# Altium schematic sheet 04_MCU.SchDoc(04_MCU)
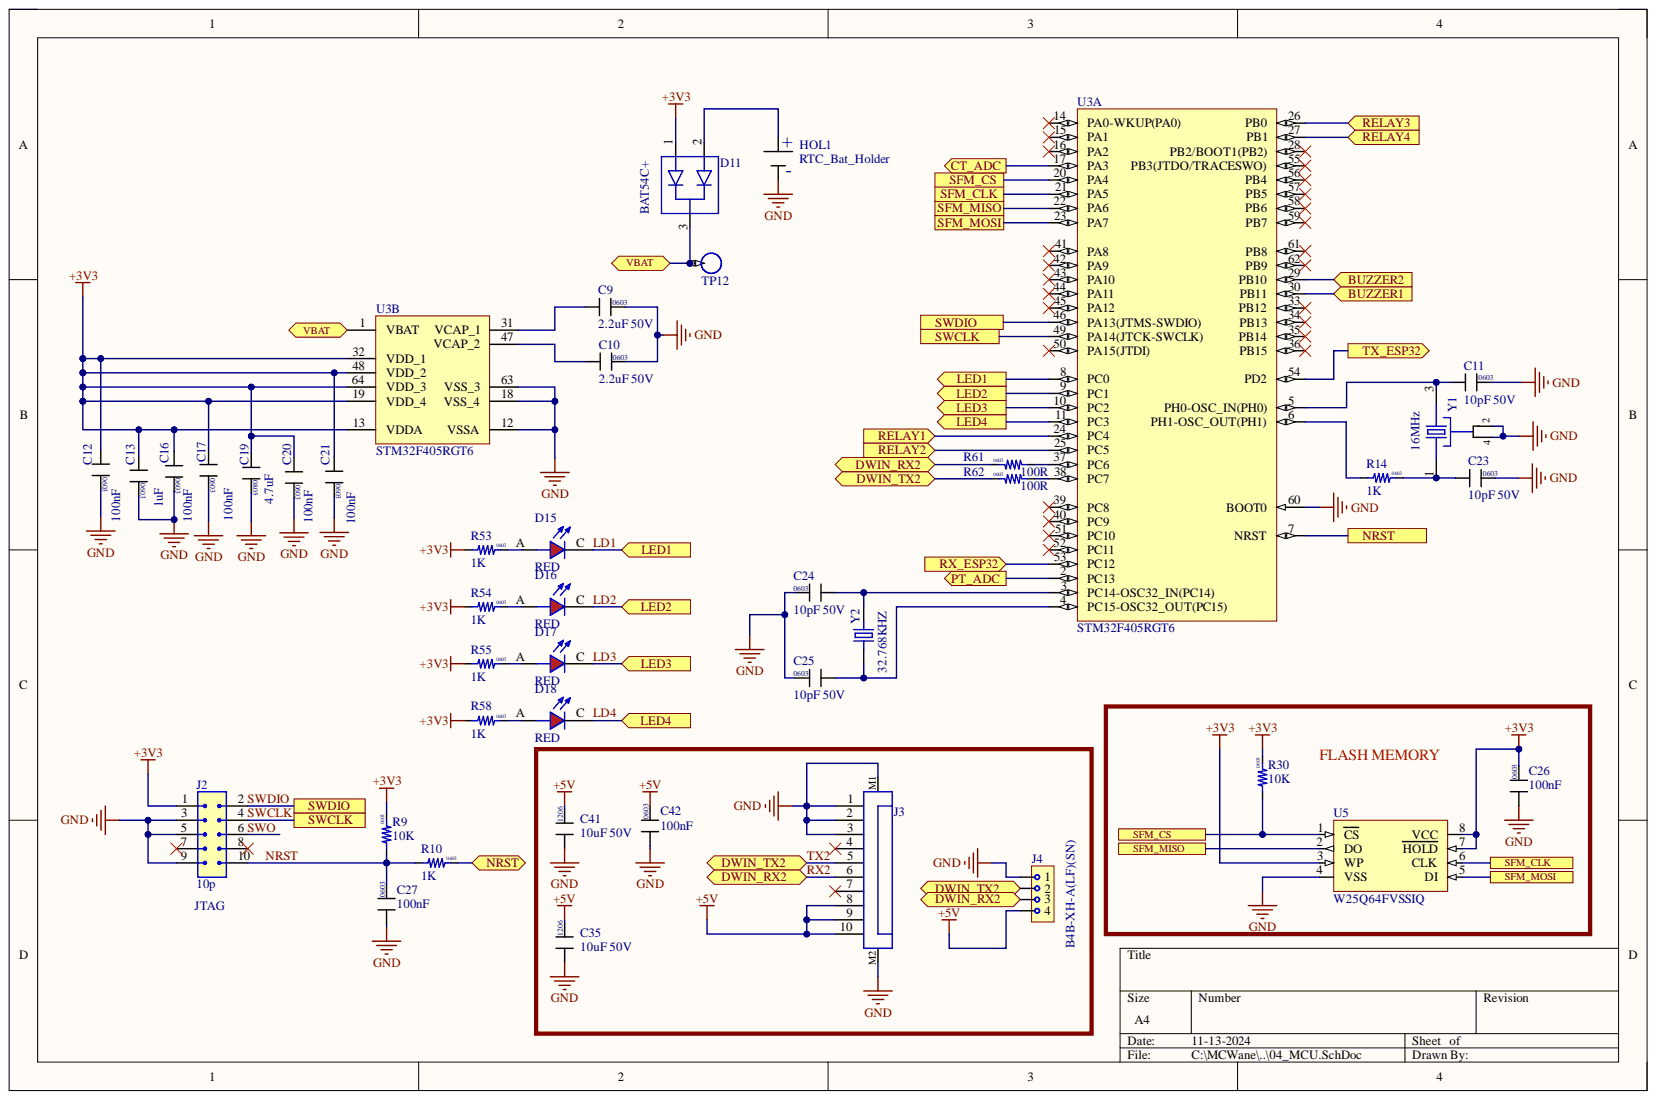
[diagram p0: 04_MCU.SchDoc]

## Parts
C9  2.2uF 50V  Package: 0603  [CAP_0603]
C10  2.2uF 50V  Package: 0603  [CAP_0603]
C11  10pF 50V  Package: 0603  [CAP_0603]
C12  100nF  Package: 0603  [CAP_0603]
C13  1uF  Package: 0603  [CAP_0603]
C16  100nF  Package: 0603  [CAP_0603]
C17  100nF  Package: 0603  [CAP_0603]
C19  4.7uF  Package: 0805  [CAP_0805]
C20  100nF  Package: 0603  [CAP_0603]
C21  100nF  Package: 0603  [CAP_0603]
C23  10pF 50V  Package: 0603  [CAP_0603]
C24  10pF 50V  Package: 0603  [CAP_0603]
C25  10pF 50V  Package: 0603  [CAP_0603]
C26  100nF  Package: 0603  [CAP_0603]
C27  100nF  Package: 0603  [CAP_0603]
C35  10uF 50V  Package: 1206  [CAP_1206]
C41  10uF 50V  Package: 1206  [CAP_1206]
C42  100nF  Package: 0603  [CAP_0603]
D11  BAT54C+  [SOT23]
D15  RED  [LED_0603]
D16  RED  [LED_0603]
D17  RED  [LED_0603]
D18  RED  [LED_0603]
HOL1  RTC_Bat_Holder  [RTC_BAT_20mm_VER]
J2  10p  [FTS-105-01-F-DV]
J3  68611014422  [FPC_10PIN_1mm_BC]  (value not drawn)
J4  B4B-XH-A(LF)(SN)  [RMC_4_PIN_M_V_S_2.54mm]
R9  10K  Package: 0603  [RES_0603]
R10  1K  Package: 0603  [RES_0603]
R14  1K  Package: 0603  [RES_0603]
R30  10K  Package: 0603  [RES_0603]
R53  1K  Package: 0603  [RES_0603]
R54  1K  Package: 0603  [RES_0603]
R55  1K  Package: 0603  [RES_0603]
R58  1K  Package: 0603  [RES_0603]
R61  100R  Package: 0603  [RES_0603]
R62  100R  Package: 0603  [RES_0603]
TP12  TP  [TEST_POINT_SMD]
U3  STM32F405RGT6  [STM-LQFP64_N]  (parts A,B)
U5  W25Q64FVSSIQ  [SOIC-8W]
Y1  16MHz  [XTAL_3.2X2.5mm]
Y2  32.768KHZ  [XTAL_ABS07-32.768KHZ-T]

## Ports
BUZZER1, BUZZER2, CT_ADC, DWIN_RX2, DWIN_TX2, LED1, LED2, LED3, LED4, NRST, PT_ADC, RELAY1, RELAY2, RELAY3, RELAY4, RX_ESP32, SFM_CLK, SFM_CS, SFM_MISO, SFM_MOSI, SWCLK, SWDIO, TX_ESP32, VBAT

## Nets
+3V3: C12.1 C13.1 C16.1 C17.1 C19.1 C20.1 C21.1 C26.2 D11.1 J2.1 R9.2 R30.2 R53.1 R54.1 R55.1 R58.1 U3.13(VDDA) U3.19(VDD_4) U3.32(VDD_1) U3.48(VDD_2) U3.64(VDD_3) U5.3(WP) U5.7(HOLD) U5.8(VCC)
+5V: C35.2 C41.2 C42.2 J3.8 J3.9 J3.10 J4.4
GND: C9.2 C10.2 C11.2 C12.2 C13.2 C16.2 C17.2 C19.2 C20.2 C21.2 C23.2 C24.2 C25.2 C26.1 C27.1 C35.1 C41.1 C42.1 HOL1.2 J2.3 J2.5 J2.9 J3.1 J3.2 J3.3 J3.M1 J3.M2 J4.1 U3.12(VSSA) U3.18(VSS_4) U3.60(BOOT0) U3.63(VSS_3) U5.4(VSS) Y1.2 Y1.4
BUZZER1: U3.30(PB11) ; port BUZZER1
BUZZER2: U3.29(PB10) ; port BUZZER2
CT_ADC: U3.17(PA3) ; port CT_ADC
LD1: D15.C U3.8(PC0) ; port LED1
LD2: D16.C U3.9(PC1) ; port LED2
LD3: D17.C U3.10(PC2) ; port LED3
LD4: D18.C U3.11(PC3) ; port LED4
NRST: C27.2 J2.10 R9.1 R10.1
PT_ADC: U3.2(PC13) ; port PT_ADC
RELAY1: U3.24(PC4) ; port RELAY1
RELAY2: U3.25(PC5) ; port RELAY2
RELAY3: U3.26(PB0) ; port RELAY3
RELAY4: U3.27(PB1) ; port RELAY4
RX2: J3.6 J4.3 R61.2 ; port DWIN_RX2
RX_ESP32: U3.53(PC12) ; port RX_ESP32
SFM_CLK: U3.21(PA5) U5.6(CLK) ; port SFM_CLK
SFM_CS: R30.1 U3.20(PA4) U5.1(CS) ; port SFM_CS
SFM_MISO: U3.22(PA6) U5.2(DO) ; port SFM_MISO
SFM_MOSI: U3.23(PA7) U5.5(DI) ; port SFM_MOSI
SWCLK: J2.4 U3.49(PA14(JTCK-SWCLK)) ; port SWCLK
SWDIO: J2.2 U3.46(PA13(JTMS-SWDIO)) ; port SWDIO
SWO: J2.6
TX2: J3.5 J4.2 R62.2 ; port DWIN_TX2
TX_ESP32: U3.54(PD2) ; port TX_ESP32
VBAT: D11.3 TP12.1 U3.1(VBAT) ; port VBAT
NetC9_1: C9.1 U3.31(VCAP_1)
NetC10_1: C10.1 U3.47(VCAP_2)
NetC11_1: C11.1 U3.5(PH0-OSC_IN(PH0)) Y1.3
NetC23_1: C23.1 R14.2 Y1.1
NetC24_1: C24.1 U3.3(PC14-OSC32_IN(PC14)) Y2.2
NetC25_1: C25.1 U3.4(PC15-OSC32_OUT(PC15)) Y2.1
NetD11_2: D11.2 HOL1.1
NetD15_A: D15.A R53.2
NetD16_A: D16.A R54.2
NetD17_A: D17.A R55.2
NetD18_A: D18.A R58.2
NetR10_2: R10.2 U3.7(NRST) ; port NRST
NetR14_1: R14.1 U3.6(PH1-OSC_OUT(PH1))
NetR61_1: R61.1 U3.37(PC6)
NetR62_1: R62.1 U3.38(PC7)

Not connected: J2 7 8; J3 4 7; U3 14(PA0-WKUP(PA0)) 15(PA1) 16(PA2) 28(PB2/BOOT1(PB2)) 33(PB12) 34(PB13) 35(PB14) 36(PB15) 39(PC8) 40(PC9) 41(PA8) 42(PA9) 43(PA10) 44(PA11) 45(PA12) 50(PA15(JTDI)) 51(PC10) 52(PC11) 55(PB3(JTDO/TRACESWO)) 56(PB4) 57(PB5) 58(PB6) 59(PB7) 61(PB8) 62(PB9)

## Library data of the parts
C9 C10 = C0603-2.2uF 50V-MLCC: SMD Multilayer Ceramic Capacitor, 2.2 µF, 50 V, 0603 [1608 Metric], ± 10%, X7R; Manufacturer Part Number: CC0603KRX5R9BB225; Brand: YAGEO; Marking: 225; Component: Capacitor; Component Kind: Standard; LCSC Part: C326557; Library Name: Passive_Components.SchLib; Supplier: ELEMENT 14
C11 C23-C25 = C0603-10pF 50V-MLCC: SMD Multilayer Ceramic Capacitor, 10 pF, 50 V, 0603 [1608 Metric], ± 10%, C0G / NP0, -55 °C; Manufacturer Part Number: CC0603KRNPO9BN100; Brand: YAGEO; Marking: 100; Component: Capacitor; Component Kind: Standard; Library Name: Passive_Components.SchLib; Supplier: ELEMENT 14; Supplier PN: C106245
C12 C16 C17 C20 C21 C26 C27 C42 = C0603-0.1uF 50V-MLCC: SMD Multilayer Ceramic Capacitor, 0.1 µF, 50 V, 0603 [1608 Metric], ± 10%, X7R; Manufacturer Part Number: CC0603KRX7R9BB104; Brand: YAGEO; Marking: 104; Component: Capacitor; Component Kind: Standard; LCSC Part: C14663; Library Name: Passive_Components.SchLib; Supplier: ELEMENT 14
C13 = C0603-1uF 50V-MLCC: SMD Multilayer Ceramic 1 µF ±10% 50V Ceramic Capacitor X7R 0603 (2012 Metric); Manufacturer Part Number: CC0603KRX7R9BB105; Brand: YAGEO; Marking: 105; Component: Capacitor; Component Kind: Standard; LCSC Part: C559769; Library Name: Passive_Components.SchLib; Supplier: ELEMENT 14
C19 = C0805-4.7uF 25V-MLCC: SMD Multilayer Ceramic Capacitor, 4.7 µF, 25 V, 0805 [2012 Metric], ± 10%, X7R, -55 °C; Manufacturer Part Number: CC0805KKX7R8BB475; Brand: YAGEO; Marking: 475; Suppplier: ELEMENT 14; Component: Capacitor; Component Kind: Standard; Library Name: Passive_Components.SchLib
C35 C41 = C1206-10uF 50V-MLCC: SMD Multilayer Ceramic Capacitor, 10 µF, 50 V, 1206 [3216 Metric], ± 10%, X5R, GRT; Manufacturer Part Number: GRT31CR61H106KE01L; Brand: MURATA; Marking: 106; Component: Capacitor; Component Kind: Standard; Library Name: Passive_Components.SchLib; Supplier: ELEMENT 14
D11 = BAT54C+: Small Signal Schottky Diode, Dual Common Cathode, 30 V, 200 mA, 400 mV, 600 mA, 125 °C; Brand: MULTICOMP PRO; Component Kind: Standard; Library Name: Active_Components.SchLib; Manufacturer Part Number: BAT54C+; Package: SOT-23
D15-D18 = RED_LED_0603: 5mA 28.5mcd 2.2V 632nm Colorless transparence -40℃~+85℃ 617.5nm~633.5nm Red 120° 60mW 0603(LED); Manufacturer Part Number: 19-217/R6C-AL1M2VY/3T; Brand: Everlight Elec; Component Kind: Standard; Library Name: Active_Components.SchLib; Package: 0603
HOL1 = RTC_Bat_Holder: Battery Holder, PCB, Vertical, CR2032; Manufacturer Part Number: MP000363; Brand: MULTICOMP PRO; Component Kind: Standard; Library Name: CONNECTOR.SchLib; Package: Through Hole
J2 = FTS-105-01-F-DV: Connector Header Surface Mount 10 position 0.050" (1.27mm); Manufacturer: Samtec Inc.; Value: 5X2 POS, 1.27mmP; Component Kind: Standard; Datasheet Web: http://suddendocs.samtec.com/catalog_english/fts.pdf; LCSC Part: C3348793; Operating Temperature: -55°C ~ 125°C; Package: SMD; PartNumber: FTS-105-01-F-DV; Type: Header - Male; Library Name: Amerex Electronics.SchLib
J3 = FPC_10PIN_1mm_BC: Horizontal Bottom Contact, pitch 1mm, Horizontal, 10pins; Brand: Wurth Elektronik; Contact Resistance: 20mΩ; Match Code: WR-FPC; Packag: SMD; Rated Current: 1A; Working Voltage: 125VAC; Category: ZIF Connectors; Component Kind: Standard; ComponentLink1Description: Website Link; ComponentLink1URL: https://www.we-online.com/redexpert/article/68611014422?ad; ComponentLink2Description: Datasheet Link; ComponentLink2URL: https://www.we-online.com/redexpert/spec/68611014422?ad; Height: 3mm; Length: 17mm; Library Name: CONNECTOR.SchLib; Manufacturer Part Number: 68611014422; Mount: Surface Mount; Number of Pins: 10; Operating Temperature Max: 85°C; Operating Temperature Min: -25°C; Orientation: Horizontal; Pitch: 1mm; Width: 7.1mm
J4 = RMC_4_PIN_M_V_S_2.54mm: Pin Header, Vertical, Wire-to-Board, 2.5 mm, 1 Rows, 4 Contacts, Through Hole Straight, XH; Brand: JST Sales America Inc.; Component Kind: Standard; Library Name: CONNECTOR.SchLib; Manufacturer Part Number: B4B-XH-A(LF)(SN); Package: TH
R9 R30 = R0603-10K-1%: SMD Chip Resistor, 10 kohm, ± 1%, 100 mW, 0603 [1608 Metric], Thick Film, General Purpose; Manufacturer Part Number: RC0603FR-0710KL; Brand: YAGEO; Marking: 1002; Component: Resistor; Component Kind: Standard; LCSC Part: C98220; Library Name: Passive_Components.SchLib; Supplier: ELEMENT 14
R10 R14 R53-R55 R58 = R0603-1K-1%: SMD Chip Resistor, 1 kohm, ± 1%, 100 mW, 0603 [1608 Metric], Thick Film, General Purpose; Manufacturer Part Number: RC0603FR-071KL; Brand: YAGEO; Marking: 1001; Component: Resistor; Component Kind: Standard; LCSC Part: C109318; Library Name: Passive_Components.SchLib; Supplier: ELEMENT 14
R61 R62 = R0603-100E-1%: SMD Chip Resistor, 100 ohm, ± 1%, 100 mW, 0603 [1608 Metric], Thick Film, General Purpose; Manufacturer Part Number: RC0603FR-07100RL; Brand: YAGEO; Component: Resistor; Component Kind: Standard; Library Name: Passive_Components.SchLib; Marking: 100R; Supplier: ELEMENT 14
TP12 = TEST_POINT_SMD: Component Kind: Standard; Library Name: CONNECTOR.SchLib
U3 = STM32F205RET6: ARM® Cortex®-M4 STM32F4 Microcontroller IC 32-Bit Single-Core 168MHz 1MB (1M x 8) FLASH 64-LQFP (10x10); Brand: Digi_Key; Core: ARM Cortex-M3; D/A Converter: 2x12-bit (2 Channel); FLASH Size (Prog) (kB): 512; I/Os (High Current): 51; Internal RAM Size (kB): 128; Operating Frequency(F) (Processor speed) (MHz): 120; Packing: Tray; Supply Voltage(Vcc) min (V): 1.8; Component Kind: Standard; ComponentLink1Description: Manufacturer URL; ComponentLink1URL: http://www.st.com/web/en/home.html; ComponentLink2Description: Datasheet; ComponentLink2URL: http://www.st.com/st-web-ui/static/active/en/resource/technical/document/datasheet/CD00237391.pdf; ComponentLink3Description: Package Specification; ComponentLink3URL: http://www.st.com/st-web-ui/static/active/en/resource/technical/document/datasheet/DM00037051.pdf; DatasheetVersion: Rev. 9; Library Name: STMicroelectronics STM32 F2.SchLib; Manufacturer Part Number: STM32F405RGT6; Mounting Technology: Surface Mount; Package: LQFP64; PackageDescription: 64-Pin Low Profile Quad Flat Package, 10 x 10 mm Body, 0.5 mm Pitch; PackageVersion: Rev. 4, 06/2013; Supplier 1: Digi-Key; Supplier 2: Farnell; Supplier 3: Newark; Supplier 4: Mouser; Supplier Part Number 1: 497-11155-ND; Supplier Part Number 2: 2060897; Supplier Part Number 3: 47T9329; Supplier Part Number 4: 511-STM32F205RET6
U5 = W25Q64FVSSIQ: FLASH - NOR Memory IC 64Mb (8M x 8) SPI - Quad I/O, QPI 104 MHz 8-SOIC; Brand: Winbond Elec; Component Kind: Standard; Library Name: Active_Components.SchLib; Manufacturer Part Number: W25Q64FVSSIQ; Package: SOIC-8
Y1 = X322516MOB4SI: 16MHz SMD Crystal Resonator 12pF ±10ppm ±30ppm -40℃~+85℃ SMD3225-4P Crystals ROHS; Brand: YXC; Component Kind: Standard; Library Name: Active_Components.SchLib; Manufacturer Part Number: X322516MOB4SI; Package: SMD 3.2X2.5mm-4P
Y2 = FC31M2-32.768-NTLNNDTL: 32.768kHz SMD Crystal Resonator 12.5pF ±10ppm ±10ppm -40℃~+85℃ SMD3215-2P Crystals ROHS; Brand: HCI; Component Kind: Standard; Library Name: Active_Components.SchLib; Manufacturer Part Number: FC31M2-32.768-NTLNNDTL; Package: SMD3215-2P; Supplier PN: C5120559
